# Altium schematic sheet ParteAnalogicaMedicion.SchDoc(ParteAnalogicaMedicion)
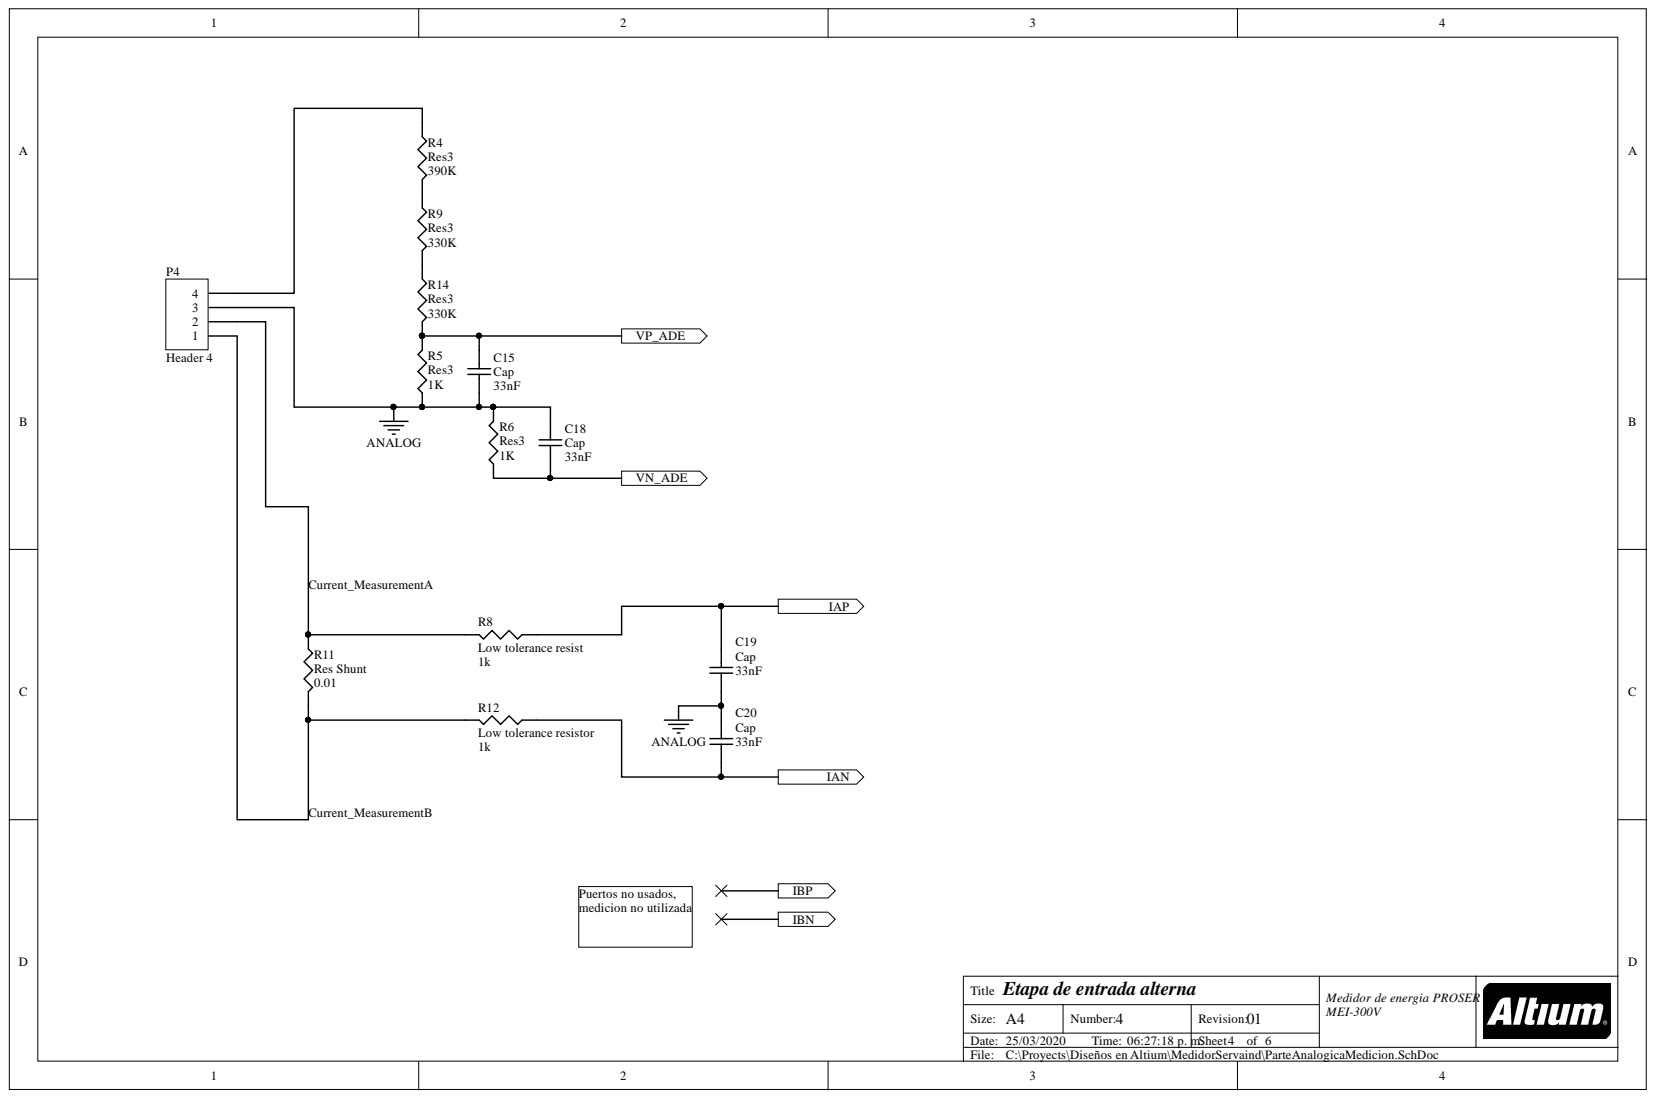
[diagram p0: ParteAnalogicaMedicion.SchDoc]

## Parts
C15  Cap  value 33nF  [Custom0805]
C18  Cap  value 33nF  [Custom0805]
C19  Cap  value 33nF  [Custom0805]
C20  Cap  value 33nF  [Custom0805]
P4  Header 4  [ConectorPrsr]
R4  Res3  value 390K  [Custom0805]
R5  Res3  value 1K  [Custom0805]
R6  Res3  value 1K  [Custom0805]
R8  Low tolerance resist  value 1k  [Custom0805]
R9  Res3  value 330K  [Custom0805]
R11  Res Shunt  value 0.01  [RESC1000X525X52L180M]
R12  Low tolerance resistor  value 1k  [Custom0805]
R14  Res3  value 330K  [Custom0805]

## Ports
IAN, IAP, IBN, IBP, VN_ADE, VP_ADE

## Nets
ANALOG: C15.1 C18.2 C19.1 C20.2 P4.3 R5.1 R6.2
CURRENT_MEASUREMENTA: P4.2 R8.2 R11.1
CURRENT_MEASUREMENTB: P4.1 R11.2 R12.2
IAN: C20.1 R12.1 ; port IAN
IAP: C19.2 R8.1 ; port IAP
VN_ADE: C18.1 R6.1 ; port VN_ADE
VP_ADE: C15.2 R5.2 R14.2 ; port VP_ADE
NetP4_4: P4.4 R4.1
NetR4_2: R4.2 R9.1
NetR9_2: R9.2 R14.1
N00175: ; port IBN
N00176: ; port IBP

## Library data of the parts
C15 C18-C20 = Cap: Capacitor; Component Kind: Standard; LatestRevisionDate: 17-Jul-2002; LatestRevisionNote: Re-released for DXP Platform.; Library Name: Miscellaneous Devices.IntLib; PackageDescription: Radial Cap, Thru-Hole; 2 Leads; 0.3 in Pin Spacing; PackageReference: RAD-0.3; Published: 8-Jun-2000; Signal Integrity: Cap; Simulation: CAP; Publisher: Altium Limited
P4 = Header 4: Header, 4-Pin; Component Kind: Standard; LatestRevisionDate: 17-Jul-2002; LatestRevisionNote: Re-released for DXP Platform.; Library Name: Miscellaneous Connectors.IntLib; Signal Integrity: Connector; Publisher: Altium Limited
R4-R6 R8 R9 R11 R12 R14 = Res3: Resistor; Component Kind: Standard; LatestRevisionDate: 29-May-2009; LatestRevisionNote: IPC-7351 Footprint Added.; Library Name: Miscellaneous Devices.IntLib; PackageDescription: Chip Resistor; PackageReference: J1-0603; Published: 8-Jun-2000; Signal Integrity: Res; Simulation: RESISTOR; Publisher: Altium Limited
